# Altium schematic sheet SystemController.SchDoc(SystemController)
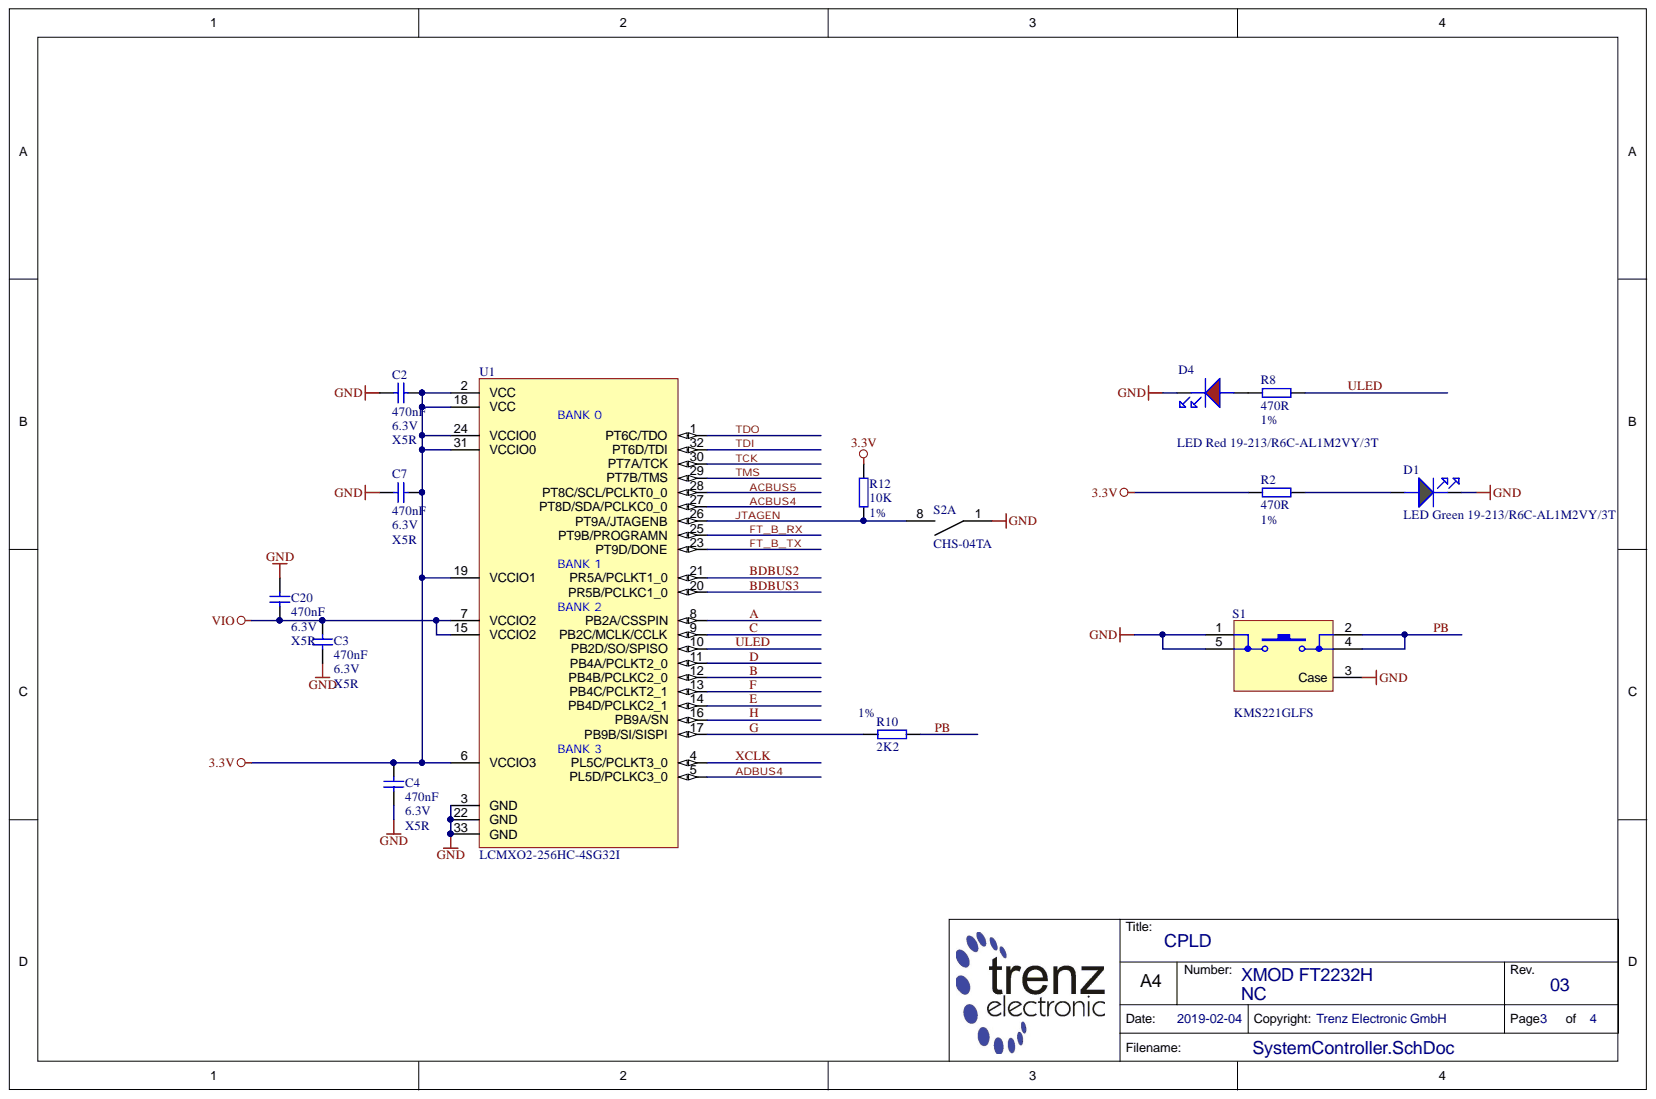
[diagram p0: SystemController.SchDoc]

## Parts
C2  470nF  Voltage: 6.3V  [CAPC0603X33N]
C3  470nF  Voltage: 6.3V  Technology: X5R  [CAPC0603X33N]
C4  470nF  Voltage: 6.3V  Technology: X5R  [CAPC0603X33N]
C7  470nF  Voltage: 6.3V  [CAPC0603X33N]
C20  470nF  Voltage: 6.3V  [CAPC0603X33N]
D1  LED Green 19-213/R6C-AL1M2VY/3T  Database Table Name: LED  [LEDC1608X55N_GREEN]
D4  LED Red 19-213/R6C-AL1M2VY/3T  [LEDC1608X55N_RED]
R2  470R  Tolerance: 1%  [RESC1005X40N]
R8  470R  Tolerance: 1%  [RESC1005X40N]
R10  2K2  Tolerance: 1%  [RESC1005X40N]
R12  10K  Tolerance: 1%  [RESC0603X26N]
S1  KMS221GLFS  [KMS2xxGLFS]
S2  CHS-04TA  [CHS-04TA]  (part A)
U1  LCMXO2-256HC-4SG32I  [PQFN50P500X500X60-33N]

## Nets
3.3V: C2.2 C4.2 C7.2 R2.2 R12.1 U1.2(VCC) U1.6(VCCIO3) U1.18(VCC) U1.19(VCCIO1) U1.24(VCCIO0) U1.31(VCCIO0)
GND: C2.1 C3.1 C4.1 C7.1 C20.1 D1.K D4.K S1.1 S1.3(Case) S1.5 S2.1 U1.3(GND) U1.22(GND) U1.33(GND)
VIO: C3.2 C20.2 U1.7(VCCIO2) U1.15(VCCIO2)
A: U1.8(PB2A/CSSPIN)
ACBUS4: U1.27(PT8D/SDA/PCLKC0_0)
ACBUS5: U1.28(PT8C/SCL/PCLKT0_0)
ADBUS4: U1.5(PL5D/PCLKC3_0)
B: U1.12(PB4B/PCLKC2_0)
BDBUS2: U1.21(PR5A/PCLKT1_0)
BDBUS3: U1.20(PR5B/PCLKC1_0)
C: U1.9(PB2C/MCLK/CCLK)
D: U1.11(PB4A/PCLKT2_0)
E: U1.14(PB4D/PCLKC2_1)
F: U1.13(PB4C/PCLKT2_1)
FT_B_RX: U1.25(PT9B/PROGRAMN)
FT_B_TX: U1.23(PT9D/DONE)
G: R10.1 U1.17(PB9B/SI/SISPI)
H: U1.16(PB9A/SN)
JTAGEN: R12.2 S2.8 U1.26(PT9A/JTAGENB)
PB: R10.2 S1.2 S1.4
TCK: U1.30(PT7A/TCK)
TDI: U1.32(PT6D/TDI)
TDO: U1.1(PT6C/TDO)
TMS: U1.29(PT7B/TMS)
ULED: R8.1 U1.10(PB2D/SO/SPISO)
XCLK: U1.4(PL5C/PCLKT3_0)
NetD1_A: D1.A R2.1
NetD4_A: D4.A R8.2

## Library data of the parts
C2-C4 C7 C20 = 24921: KON 470nF 6.3V X5R 20%  SMD BF 0603 (0201); Value: 470n; Temperature-Range: -55/+85; Preferred: Yes; Mixed: SMT; Database Table Name: C_0603_0201; Tolerance: 20%; Library Name: TE.DbLib; Component Kind: Standard; Case: 0603 (0201); AN: 24921
  C2 C7 C20: Technology: X5R
D1 = 28262: Chip LED 0603 Briliant Green; Temperature-Range: -40/+85 (Abs); Mixed: SMT; H-ART: 19-213/G6C-BM1N2/DT; Library Name: TE.DbLib; Component Kind: Standard; Case: 1608 (0603); AN: 28262; HelpURL: b:\AD\adlib\datasheet\19-213-G6C-BM1\
D4 = 28261: Chip LED 0603 Briliant Red; Mixed: SMT; Library Name: TE.DbLib; H-ART: 19-213/R6C-AL1M2VY/3T; Database Table Name: LED; Component Kind: Standard; Case: 1608 (0603); AN: 28261; HelpURL: b:\AD\adlib\datasheet\19-213-R6C\
R2 R8 = 22769: WID SMD 470R 1% 0,063W BF 1005 (0402); Value: 470; Preferred: Yes; Mixed: SMT; Database Table Name: R_1005_0402; Technology: TK100; Library Name: TE.DbLib; Component Kind: Standard; Case: 1005 (0402); AN: 22769
R10 = 22748: WID SMD 2K2 1% 0,063W BF 1005 (0402); Value: 2.2K; Preferred: Yes; Mixed: SMT; H-ART: RC0402FR-132K2L; Database Table Name: R_1005_0402; Technology: TK100; Library Name: TE.DbLib; Component Kind: Standard; Case: 1005 (0402); AN: 22748
R12 = 24914: WID SMD 10K 1% 0,05W BF 0603 (0201); Preferred: Yes; Mixed: SMT; H-ART: RC0201FR-0710KL; Database Table Name: R_0603_0201; Value: 10K; Technology: TK100; Library Name: TE.DbLib; Component Kind: Standard; Case: 0603 (0201); AN: 24914; HelpURL: B:\AD\adlib\datasheet\R0201
S1 = 26229: KMS221GLFS - SWITCH, SPST, 0.05A, 32VDC, SMD; Mixed: SMT; Database Table Name: PB_SW; Library Name: TE.DbLib; H-ART: KMS221GLFS; Component Kind: Standard; AN: 26229; HelpURL: B:\AD\adlib\datasheet\KMS
S2 = 23417: DIP Schalter 4 fach 1,27mm SMD; Trenz-Stock: Yes; Mixed: SMT; Database Table Name: PB_SW; Preferred: Yes; Library Name: TE.DbLib; H-ART: CHS-04TA; Component Kind: Standard; AN: 23417; HelpURL: B:\AD\adlib\datasheet\CHS
U1 = 26395: Trenz-Stock: Yes; Temperature-Range: -40/+85; Mixed: SMT; Database Table Name: PROG_LOGIC; Preferred: Yes; Library Name: TE.DbLib; H-ART: LCMXO2-256HC-4SG32I; Description: LCMXO2-256HC-4SG32I; Component Kind: Standard; Case: QFN32; AN: 26395; HelpURL: B:\AD\adlib\datasheet\MachXO2
